# Altium schematic sheet USB-SD.SchDoc(USB-SD)
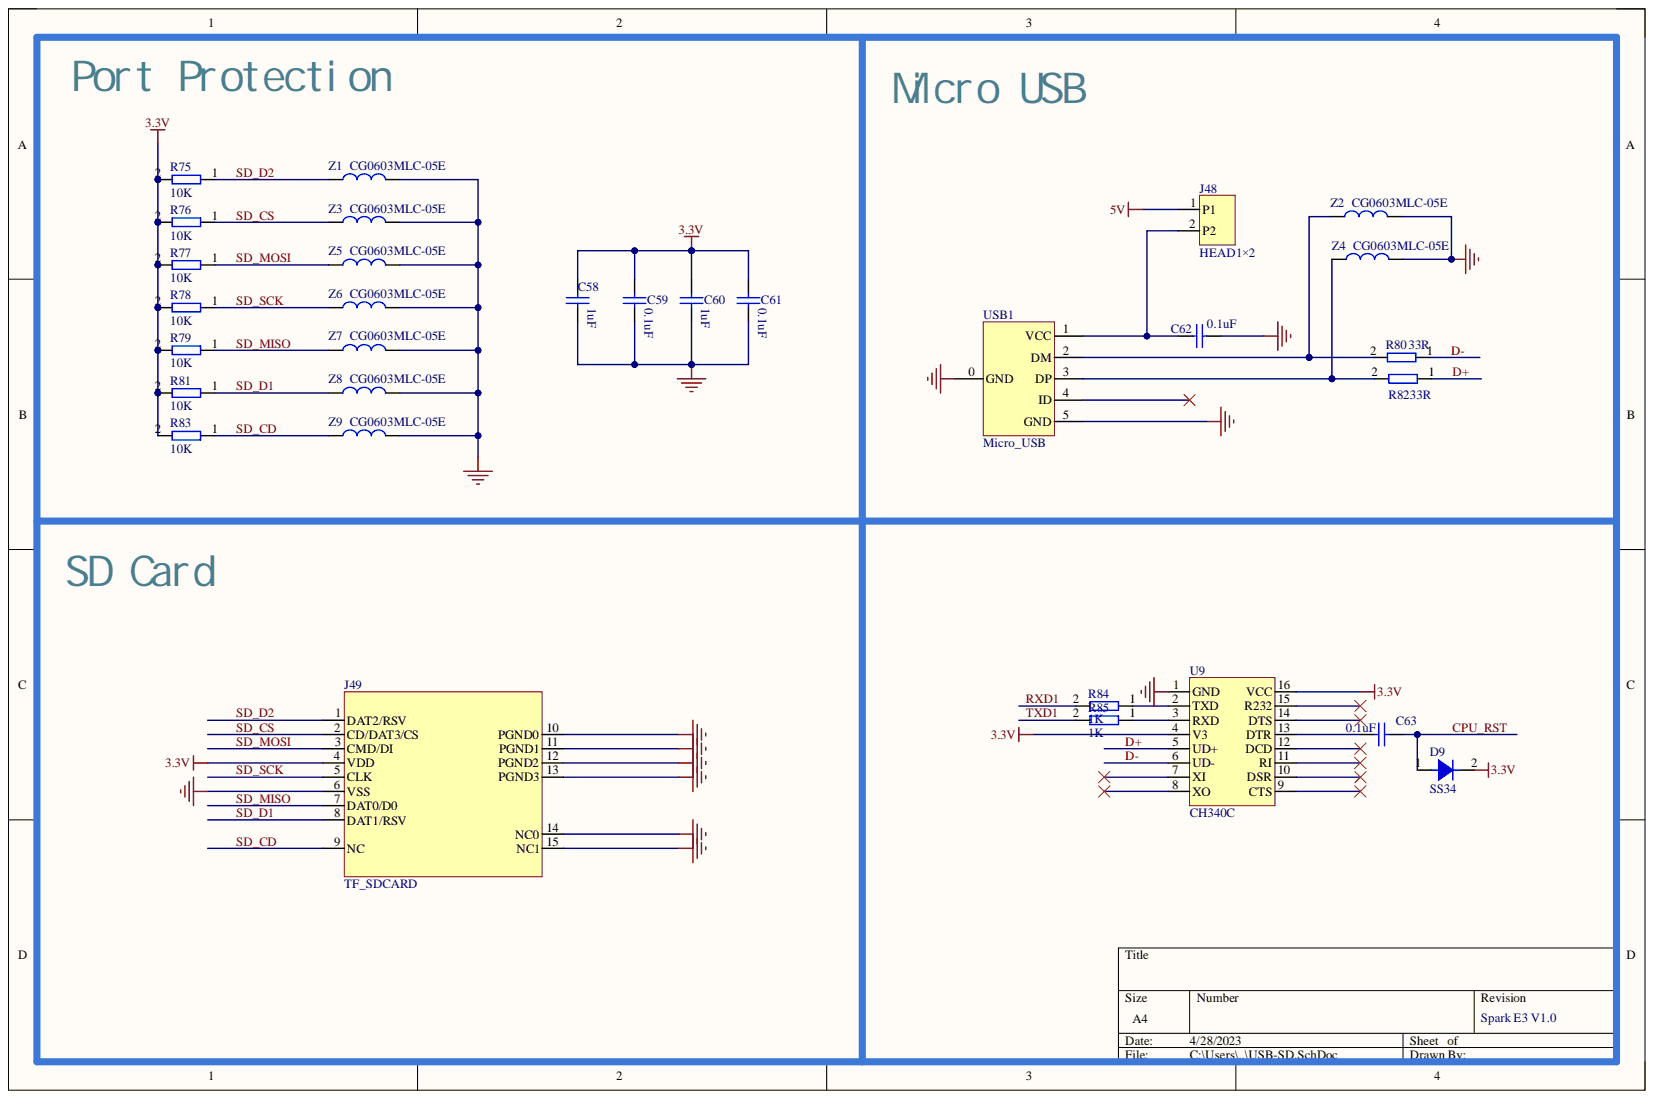
[diagram p0: USB-SD.SchDoc]

## Parts
C58  1uF  [C0603]
C59  0.1uF  [C0603]
C60  1uF  [C0603]
C61  0.1uF  [C0603]
C62  0.1uF  [C0603]
C63  0.1uF  [C0603]
D9  SS34  [SS34]
J48  HEAD1×2  [DIP/2.54/2p]
J49  TF_SDCARD  [TF_SDCARD]
R75  10K  [R0603]
R76  10K  [R0603]
R77  10K  [R0603]
R78  10K  [R0603]
R79  10K  [R0603]
R80  33R  [R0603]
R81  10K  [R0603]
R82  33R  [R0603]
R83  10K  [R0603]
R84  1K  [R0603]
R85  1K  [R0603]
U9  CH340C  [SOP16]
USB1  Micro_USB  [MINI-USB 母头/5P-0.65  四脚平口 DIP]
Z1  CG0603MLC-05E  [R0603]
Z2  CG0603MLC-05E  [R0603]
Z3  CG0603MLC-05E  [R0603]
Z4  CG0603MLC-05E  [R0603]
Z5  CG0603MLC-05E  [R0603]
Z6  CG0603MLC-05E  [R0603]
Z7  CG0603MLC-05E  [R0603]
Z8  CG0603MLC-05E  [R0603]
Z9  CG0603MLC-05E  [R0603]

## Nets
3.3V: C58.1 C59.1 C60.1 C61.1 D9.2 J49.4(VDD) R75.2 R76.2 R77.2 R78.2 R79.2 R81.2 R83.2 U9.4(V3) U9.16(VCC)
5V: J48.1
GND: C58.2 C59.2 C60.2 C61.2 C62.1 J49.6(VSS) J49.10(PGND0) J49.11(PGND1) J49.12(PGND2) J49.13(PGND3) J49.14(NC0) J49.15(NC1) U9.1(GND) USB1.0(GND) USB1.5(GND) Z1.2 Z2.2 Z3.2 Z4.2 Z5.2 Z6.2 Z7.2 Z8.2 Z9.2
CPU_RST: C63.1 D9.1
D+: R82.1 U9.5(UD+)
D-: R80.1 U9.6(UD-)
RXD1: R84.2
SD_CD: J49.9(NC) R83.1 Z9.1
SD_CS: J49.2(CD/DAT3/CS) R76.1 Z3.1
SD_D1: J49.8(DAT1/RSV) R81.1 Z8.1
SD_D2: J49.1(DAT2/RSV) R75.1 Z1.1
SD_MISO: J49.7(DAT0/D0) R79.1 Z7.1
SD_MOSI: J49.3(CMD/DI) R77.1 Z5.1
SD_SCK: J49.5(CLK) R78.1 Z6.1
TXD1: R85.2
NetC62_2: C62.2 J48.2 USB1.1(VCC)
NetC63_2: C63.2 U9.13(DTR)
NetR80_2: R80.2 USB1.2(DM) Z2.1
NetR82_2: R82.2 USB1.3(DP) Z4.1
NetR84_1: R84.1 U9.2(TXD)
NetR85_1: R85.1 U9.3(RXD)

Not connected: U9 7(XI) 8(XO) 9(CTS) 10(DSR) 11(RI) 12(DCD) 14(DTS) 15(R232); USB1 4(ID)

## Library data of the parts
C58-C63 = 电容: Component Kind: Standard; LatestRevisionDate: 17-Jul-2002; LatestRevisionNote: Re-released for DXP Platform.; PackageDescription: Radial Cap, Thru-Hole; 2 Leads; 0.3 in Pin Spacing; PackageReference: RAD-0.3; Published: 8-Jun-2000; Signal Integrity: Cap; Simulation: CAP; Value: ?; Library Name: MYschlib.SchLib; Publisher: Altium Limited
D9 = 肖特基/SS34: Component Kind: Standard; VALUE: SS34; Library Name: MYschlib.SchLib
R75-R85 = 电阻: Component Kind: Standard; value: ?; Library Name: MYschlib.SchLib
U9 = CH340G: Component Kind: Standard; Library Name: jietai-ADLB.SchLib
Z1-Z9 = 电感: Component Kind: Standard; Library Name: MYschlib.SchLib
J48 = HEAD1×2: Component Kind: Standard; Library Name: jietai-ADLB.SchLib
J49 = TF_SDCARD: Component Kind: Standard; Library Name: jietai-ADLB.SchLib
USB1 = Micro_USB: Component Kind: Standard; Library Name: jietai-ADLB.SchLib
